# Altium schematic sheet RF_Xbee.SchDoc(RF_Xbee)
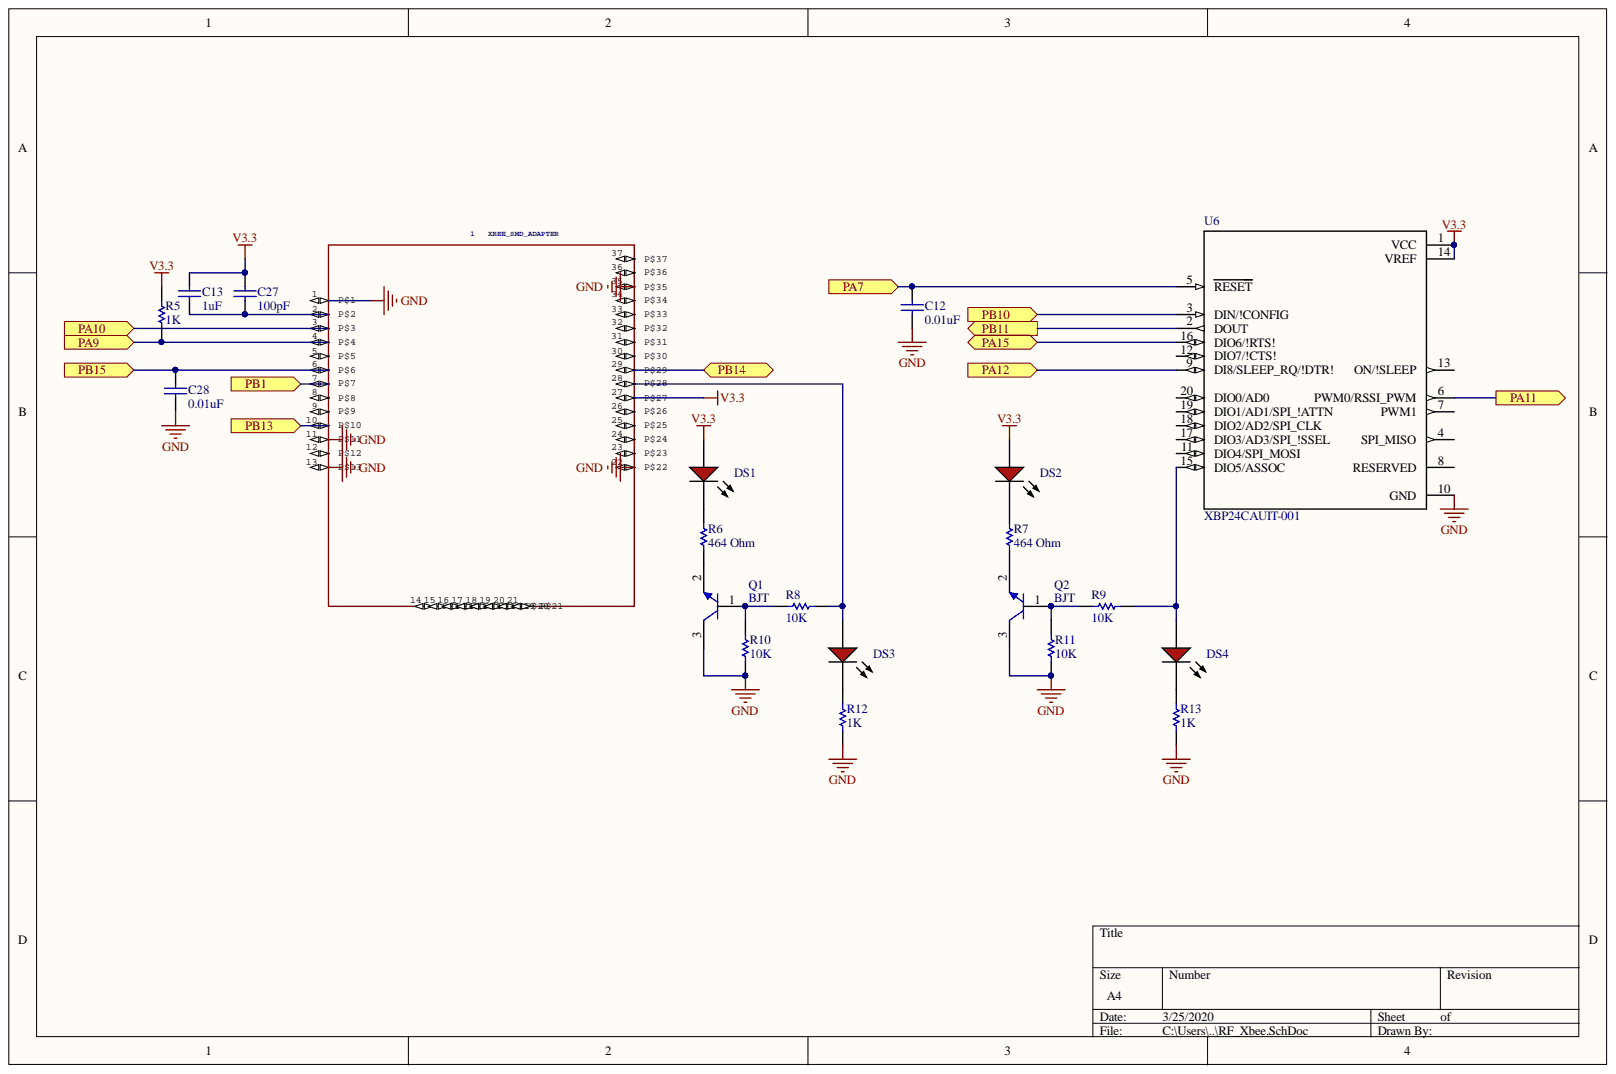
[diagram p0: RF_Xbee.SchDoc]

## Parts
1  XBEE_SMD_ADAPTER  [XBEE_SMD_ADAPTER]
C12  0.01uF  [CAPC1206(3216)170_M]
C13  1uF  [CAPC3216X180X50ML20T25]
C27  100pF  [CAPC2013X88X50ML20T25]
C28  0.01uF  [CAPC1206(3216)170_M]
DS1  HSMH-C150  [AVAG-HSMX-C150_V]  (value not drawn)
DS2  HSMH-C150  [AVAG-HSMX-C150_V]  (value not drawn)
DS3  HSMH-C150  [AVAG-HSMX-C150_V]  (value not drawn)
DS4  HSMH-C150  [AVAG-HSMX-C150_V]  (value not drawn)
Q1  BJT  [ONSC-SOT-23-3-318-08_V]
Q2  BJT  [ONSC-SOT-23-3-318-08_V]
R5  1K  [RESC2013X50X30NL20T20]
R6  464 Ohm  [RESC3216X70X50NL05T20]
R7  464 Ohm  [RESC3216X70X50NL05T20]
R8  10K  [RESC1609X50X30NL8T20]
R9  10K  [RESC1609X50X30NL8T20]
R10  10K  [RESC1609X50X30NL8T20]
R11  10K  [RESC1609X50X30NL8T20]
R12  1K  [RESC2013X50X30NL20T20]
R13  1K  [RESC2013X50X30NL20T20]
U6  XBP24CAUIT-001  [*MODULE_XBP24CAUIT-001]

## Ports
PA7, PA9, PA10, PA11, PA12, PA15, PB1, PB10, PB11, PB13, PB14, PB15

## Nets
GND: 1.1 1.11 1.13 1.22 1.35 C12.1 C28.1 Q1.3 Q2.3 R10.2 R11.2 R12.1 R13.1 U6.10(GND)
V3.3: 1.27 C13.2 C27.2 DS1.1 DS2.1 R5.2 U6.1(VCC) U6.14(VREF)
PA7: C12.2 U6.5(RESET) ; port PA7
PA9: 1.4 R5.1 ; port PA9
PA10: 1.3 ; port PA10
PA11: U6.6(PWM0/RSSI_PWM) ; port PA11
PA12: U6.9(DI8/SLEEP_RQ/!DTR!) ; port PA12
PA15: U6.16(DIO6/!RTS!) ; port PA15
PB1: 1.7 ; port PB1
PB10: U6.3(DIN/!CONFIG) ; port PB10
PB11: U6.2(DOUT) ; port PB11
PB13: 1.10 ; port PB13
PB14: 1.29 ; port PB14
PB15: 1.6 C28.2 ; port PB15
Net1_2: 1.2 C13.1 C27.1
Net1_28: 1.28 DS3.1 R8.1
NetDS1_2: DS1.2 R6.2
NetDS2_2: DS2.2 R7.2
NetDS3_2: DS3.2 R12.2
NetDS4_1: DS4.1 R9.1 U6.15(DIO5/ASSOC)
NetDS4_2: DS4.2 R13.2
NetQ1_1: Q1.1 R8.2 R10.1
NetQ1_2: Q1.2 R6.1
NetQ2_1: Q2.1 R9.2 R11.1
NetQ2_2: Q2.2 R7.1

Not connected: 1 5 8 9 12 14 15 16 17 18 19 20 21 23 24 25 26 30 31 32 33 34 36 37; U6 4(SPI_MISO) 7(PWM1) 8(RESERVED) 11(DIO4/SPI_MOSI) 12(DIO7/!CTS!) 13(ON/!SLEEP) 17(DIO3/AD3/SPI_!SSEL) 18(DIO2/AD2/SPI_CLK) 19(DIO1/AD1/SPI_!ATTN) 20(DIO0/AD0)

## Library data of the parts
1 = XBEE_SMD_ADAPTER: GateName_1: G$1; SymbolName_1: XBEE_SMD_ADAPTER; Package: XBEE_SMD_ADAPTER; DeviceSetName: XBEE_SMD_ADAPTER; Component Kind: Standard; LibraryName: UBCSolar; Library Name: UBCSolar.SCHLIB
C12 C28 = CMP-1037-03508-1: CAP 10nF 50V ±5% 1206 (3216 Metric) Thickness 1.7mm SMD; Tolerance: Â±5%; Case-Metric: 3216; Case-EIA: 1206; Value: 10nF; Technology: SMT; Rated Voltage: 50 V; Max Thickness: 1.7 mm; Library Name: Altium Content Vault; Component Kind: Standard
C13 = CMP-2000-04991-1: Chip Capacitor, 1 uF, +/- 10%, 25 V, -55 to 125 degC, 1206 (3216 Metric), RoHS, Tape and Reel; Manufacturer: Kemet; Voltage Rating DC: 25V; Type: SMDMLCCX7R6.3-250VDCCommericalGrade; Temperature Coefficient / Code: +/-15%; Dielectric: X7R; Class: Class1; Case Width: 1.6mm; Case Length: 3.2mm; Capacitance - nF: 1000nF; Voltage Rating: 25V; Tolerance: 10%; Termination Style: SMD/SMT; Series: C1206C; RoHS: Details; Product Category: MultilayerCeramicCapacitorsMLCC-SMD/SMT; Product: GeneralTypeMLCCs; Packaging: Reel; Package Reference: 1206; Package Description: 2-Pin Surface Mount Device, Body 3.2 x 1.6 mm; Package / Case: 1206(3216metric); Operating Temperature Range: -55Cto+125C; Minimum Operating Temperature: -55C; Maximum Operating Temperature: +125C; Manufacturer URL: http://www.kemet.com/; Library Name: Altium Content Vault; Factory Pack Quantity: 2000; Dimensions: 1.6mmWx3.2mmLx1.6mmH; Datasheet Version: Jul-14; Datasheet URL: https://ciiva.com/datasheet-click-18194173?src=ciiva&sig=6daff8c4; Component Kind: Standard; Case Height: 1.6mm; Case Code - mm: 3216; Case Code - in: 1206; Capacitance: 1uF; Brand: Kemet; : C1206C105K3RAC7800
C27 = CMP-2007-00223-1: Part Number: C0805C101J2GACTU; Manufacturer: Kemet; Library Name: Altium Content Vault; Component Kind: Standard
DS1-DS4 = CMP-2000-06426-1: LED Uni-Color Red, 65 mW, 25 mA, -40 to 85 degC, 2-Pin SMD, RoHS, Tape and Reel; Manufacturer: Avago; Viewing Angle: 170deg; Test Current (It): 20mA; Shape Type: Rectangular; Reverse Voltage: 5V; Rad Hardened: No; Product Length (mm): 3.2mm; Product Height (mm): 1.1mm; Product Depth (mm): 1.6mm; Power Dissipation: 78W; Peak Wavelength: 660nm; Number of  Elements: 1; Main Category: ChipLED; Lum Intensity: 17mcd; Lens Type: ColorlessDiffused; Forward Voltage: 2.6V; Forward Current: 25mA; Dominant Wave Length: 639nm; DELETED: Compliant; Chip Material: GaAlAs; Type: Uni-Color; Pin Count: 2; Packaging: TapeandReel; Package Type: ChipLed; Package Reference: HSMX-C150; Package Description: 2-Pin Surface Mount Device, Body 3.2 x 1.6 mm; Operating Temp Range: -40Cto85C; Operating Temperature Classification: Industrial; Mounting Technology: SurfaceMount; Mounting: SurfaceMount; Manufacturer URL: http://www.avagotech.com/; Luminous Intensity: 17mcd; Library Name: Altium Content Vault; Lens Dimensions: 2x1.6x0.6mm; High Brightness: No; Emitting Color: Red; Datasheet Version: 03/2012; Datasheet URL: http://media.digikey.com/pdf/Data%20Sheets/Avago%20PDFs/HSMz-Czzz.pdf; Component Kind: Standard
Q1 Q2 = CMP-1048-00631-1: General Purpose Transistor, NPN Silicon, 3-Pin SOT-23, Pb-Free, Tape and Reel; PartNumber: MMBT3904LT1G; Manufacturer: On Semiconductor; IC Continuous (A): 0.2; fT Min (MHz): 300; RoHS: TRUE; Polarity: NPN; Packing: Tape and Reel; PackageVersion: Rev. AP, 11/2009; PackageReference: SOT-23-3-318-08; PackageDescription: 3-Pin Small Outline Transistor, Body 2.92 x 1.3 mm, Pitch 0.95 mm; Mounting Technology: Surface Mount; Library Name: Altium Content Vault; DatasheetVersion: Rev. 12; ComponentLink3URL: http://www.onsemi.cn/pub_link/Collateral/318-08.PDF; ComponentLink3Description: Package Specification; ComponentLink2URL: http://www.onsemi.cn/pub_link/Collateral/MMBT3904LT1-D.PDF; ComponentLink2Description: Datasheet; ComponentLink1URL: http://www.onsemi.com/; ComponentLink1Description: Manufacturer URL; Component Kind: Standard
R5 R12 R13 = CMP-2001-03595-1: Part Number: CRCW08051K00FKEA; Manufacturer: Vishay Dale; Library Name: Altium Content Vault; Component Kind: Standard
R6 R7 = CMP-2003-00383-1: Part Number: ERJ-8ENF4640V; Manufacturer: Panasonic; Library Name: Altium Content Vault; Component Kind: Standard
R8-R11 = CMP-1666-00005-4: Chip Resistor, 10 KOhm, +/- 1%, 100 mW, -55 to 155 degC, 0603 (1608 Metric), RoHS, Tape and Reel; Manufacturer: Vishay Semiconductor; Voltage Rating: 75 V; RoHSCompliant: true; REACH SVHC: Unknown; Packaging: Tape and Reel; PackageReference: 0603; Package Quantity: 5000; PackageDescription: 2-Pin Surface Mount Device, Body 1.575 x 0.85 mm; Mounting Technology: Surface Mount; Min Operating Temperature: -55 degC; Max Operating Temperature: 155 degC; Library Name: Altium Content Vault; Length: 1.55 mm; Height: 0.45 mm; DatasheetVersion: 06/2012; ComponentLink2URL: https://datasheet.ciiva.com/11809/0900766b80d98afc-11809037.pdf; ComponentLink2Description: Datasheet; ComponentLink1URL: http://www.vishay.com/; ComponentLink1Description: Manufacturer URL; Component Kind: Standard
U6 = XBP24CAUIT-001: 802.15.4 Transceiver Module 2.4GHz Antenna Not Included, U.FL Through Hole; MF: Digi International; SnapEDA_Link: https://www.snapeda.com/parts/XBP24CAUIT-001/Digi%20International/view-part/1219456/?ref=snap; Price: None; Package: Module Digi International; MP: XBP24CAUIT-001; Library Name: XBP24CAUIT-001.IntLib; Component Kind: Standard; Availability: Unavailable
